# Altium schematic sheet MAIN_GPS.SchDoc(MAIN_GPS)
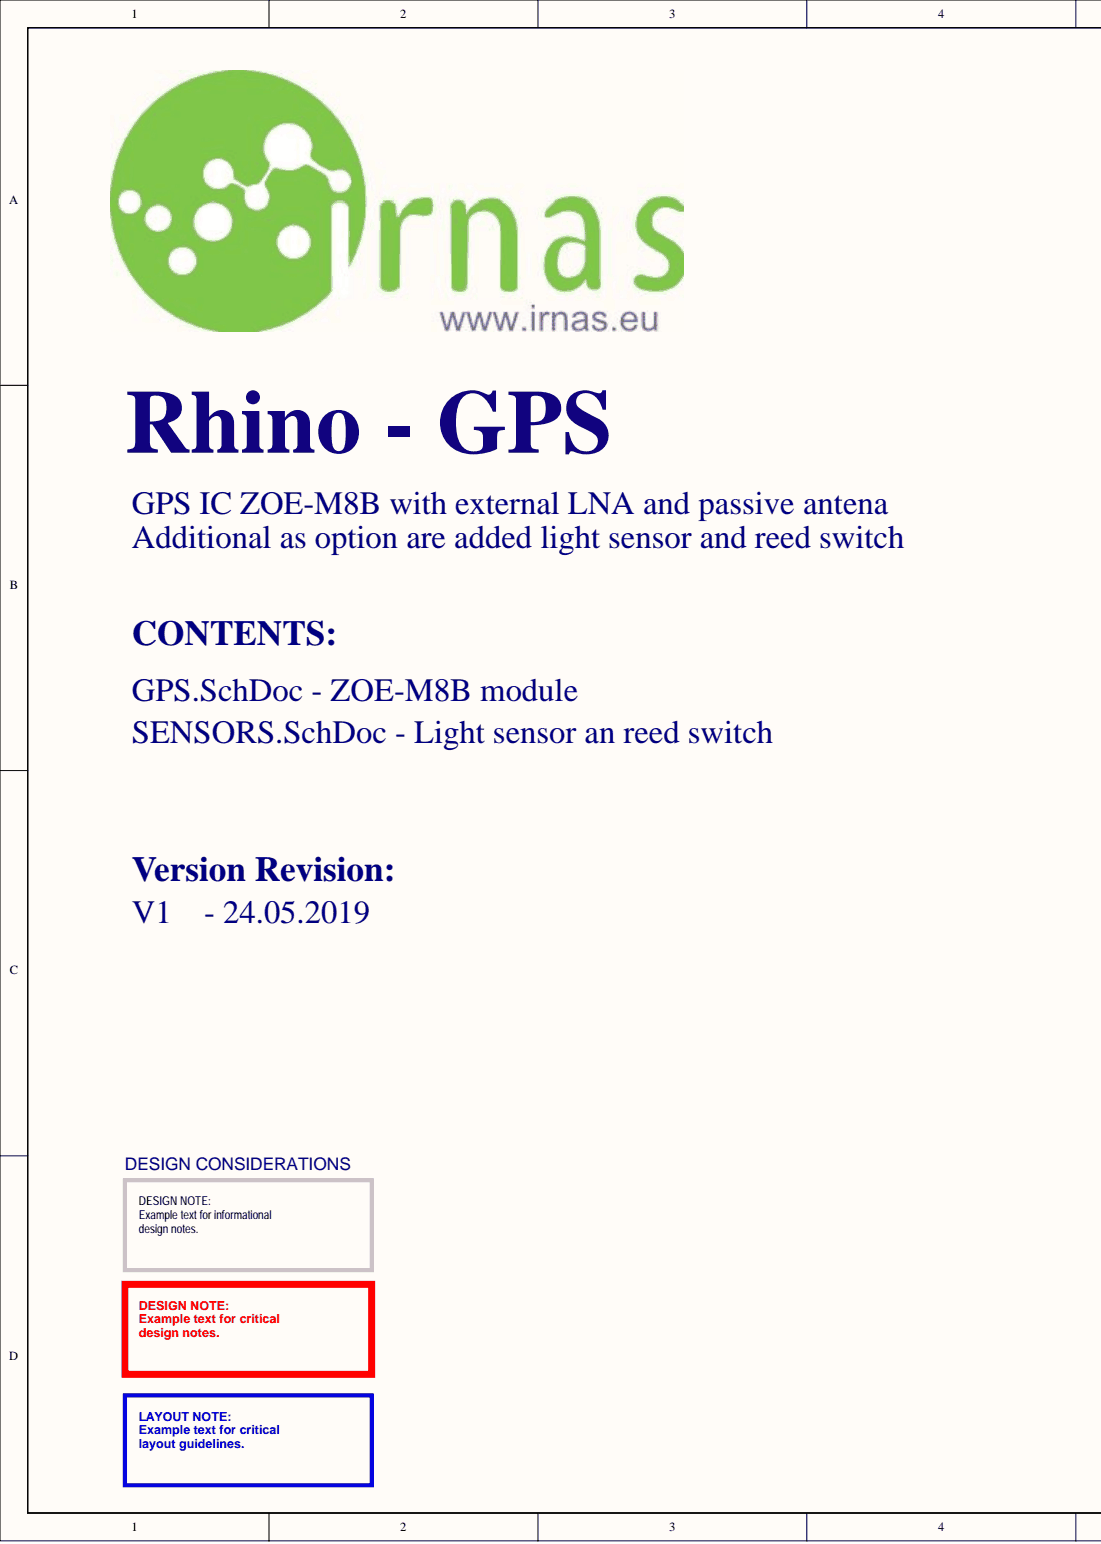
[diagram p0: MAIN_GPS.SchDoc, left]
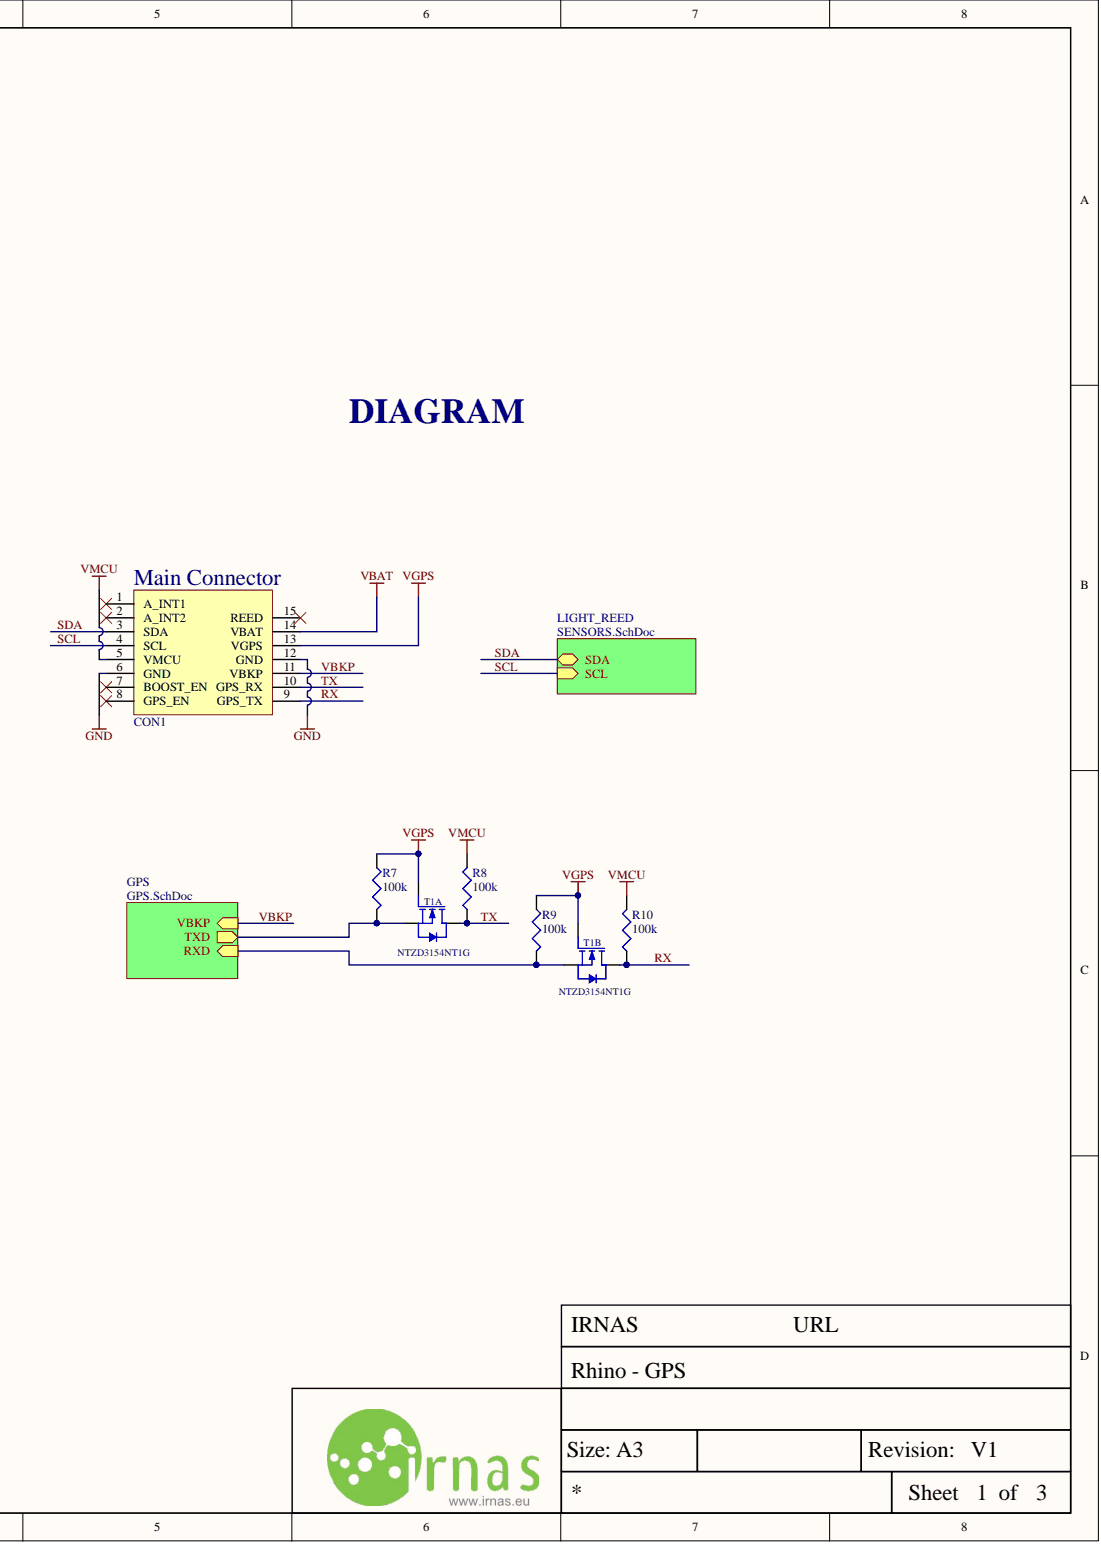
[diagram p1: MAIN_GPS.SchDoc, right]

## Parts
CON1  Between PCB connection  [SmartParks_Rhino]  (value not drawn)
R7  100k  [RES0402]
R8  100k  [RES0402]
R9  100k  [RES0402]
R10  100k  [RES0402]
T1  NTZD3154NT1G  [SOT-563]  (parts A,B)

## Nets
GND: CON1.6(GND) CON1.12(GND)
VBAT: CON1.14(VBAT)
VGPS: CON1.13(VGPS) R7.1 R9.1 T1.2 T1.5
VMCU: CON1.5(VMCU) R8.2 R10.2
RX: CON1.9(GPS_TX) R10.1 T1.3
SCL: CON1.4(SCL)
SDA: CON1.3(SDA)
TX: CON1.10(GPS_RX) R8.1 T1.6
VBKP: CON1.11(VBKP)
NetCON1_1: CON1.1(A_INT1)
NetCON1_2: CON1.2(A_INT2)
NetCON1_7: CON1.7(BOOST_EN)
NetCON1_8: CON1.8(GPS_EN)
NetCON1_15: CON1.15(REED)
NetR7_2: R7.2 T1.1
NetR9_2: R9.2 T1.4

## Library data of the parts
CON1 = SmartParks_Rhino: Library Name: Connectors.SchLib; Description: Between PCB connection; Component Kind: Standard
R7-R10 = R - 100k/0402: MULTICOMP   MCWR04X1003FTL   RES, THICK FILM, 100KOHM, 1%, 0.0625W; IRNAS Part No.: I-100013; Voltage Rating (DC): 50V; Temperature Coefficient: 100ppm/°C; Resistance: 100kR; Power Rating: 62.5mW; Voltage Rating: 50V; Tolerance: 1%; Supplier Part Number 1: 2447094; Supplier 1: Farnell; Stock 1: 161188; REACH SVHC: No SVHC; Pricing 1: 10=0.0029, 100=0.0017, 500=0.0012, 2500=0.001, 5000=0.0008 (EUR); Packaging: Cut Tape; Mount: Surface Mount; Manufacturer Part Number: MCWR04X1003FTL; Manufacturer: Multicomp; Library Name: Passive.SchLib; Composition: Thick Film; ComponentLink1URL: https://datasheet.ciiva.com/46386/1849611-46386524.pdf; ComponentLink1Description: https://datasheet.ciiva.com/46386/1849611-46386524.pdf; Component Kind: Standard; Case Code (Metric): 1005; Case Code (Imperial): 0402; Case/Package: 0402
T1 = T_M - NTZD3154NT1G: Dual MOSFET, Dual N Channel, 540 mA, 20 V, 0.4 ohm, 4.5 V, 1 V; Velikost / Size: SOT23; Value: BSS123; Opis / Description: MOSFET BSS123       /N-Channel; Komp / Device: SMD; FIT: 0.44; ELRAD Id sifra / ELRAD Id No: 597778; Supplier Part Number 1: 2533209; Supplier 1: Farnell; Library Name: Active.SchLib; Date: 11.07.2018; ComponentLINK2URL: http://www.onsemi.com/pub/Collateral/BSS123-D.PDF; ComponentLINK2Description: Datasheet www; ComponentLINK1Description: Datasheet; Component Kind: Standard; ComponentLINK1URL: c:\ELRADSVN\Altium_Library\Libraries\Active\Datasheet\BSS123.pdf; Author: Ciril Golob
